# Altium schematic sheet HeartAware_AnalogSensorControl.SchDoc
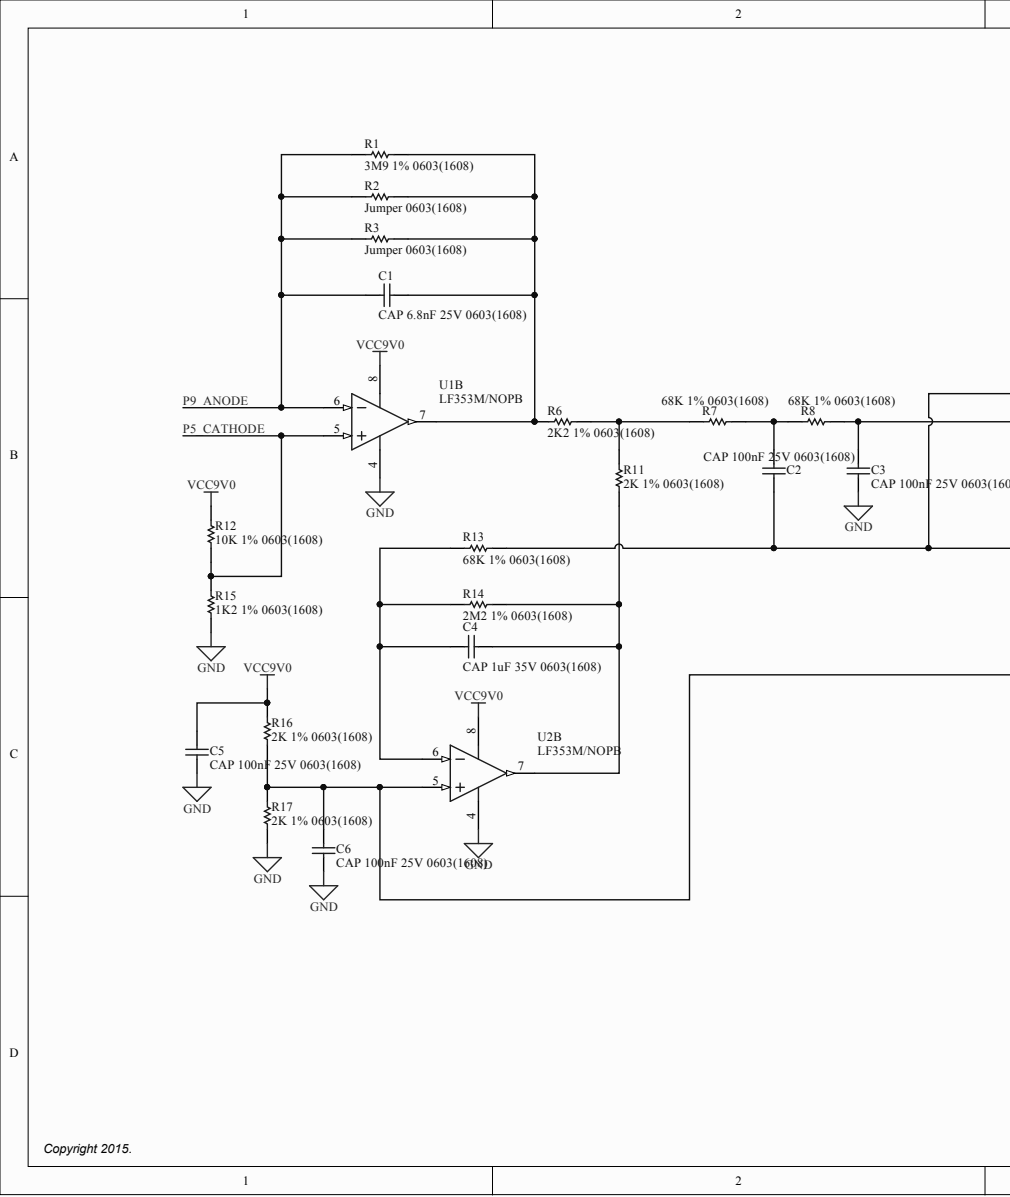
[diagram p0: HeartAware_AnalogSensorControl.SchDoc, left]
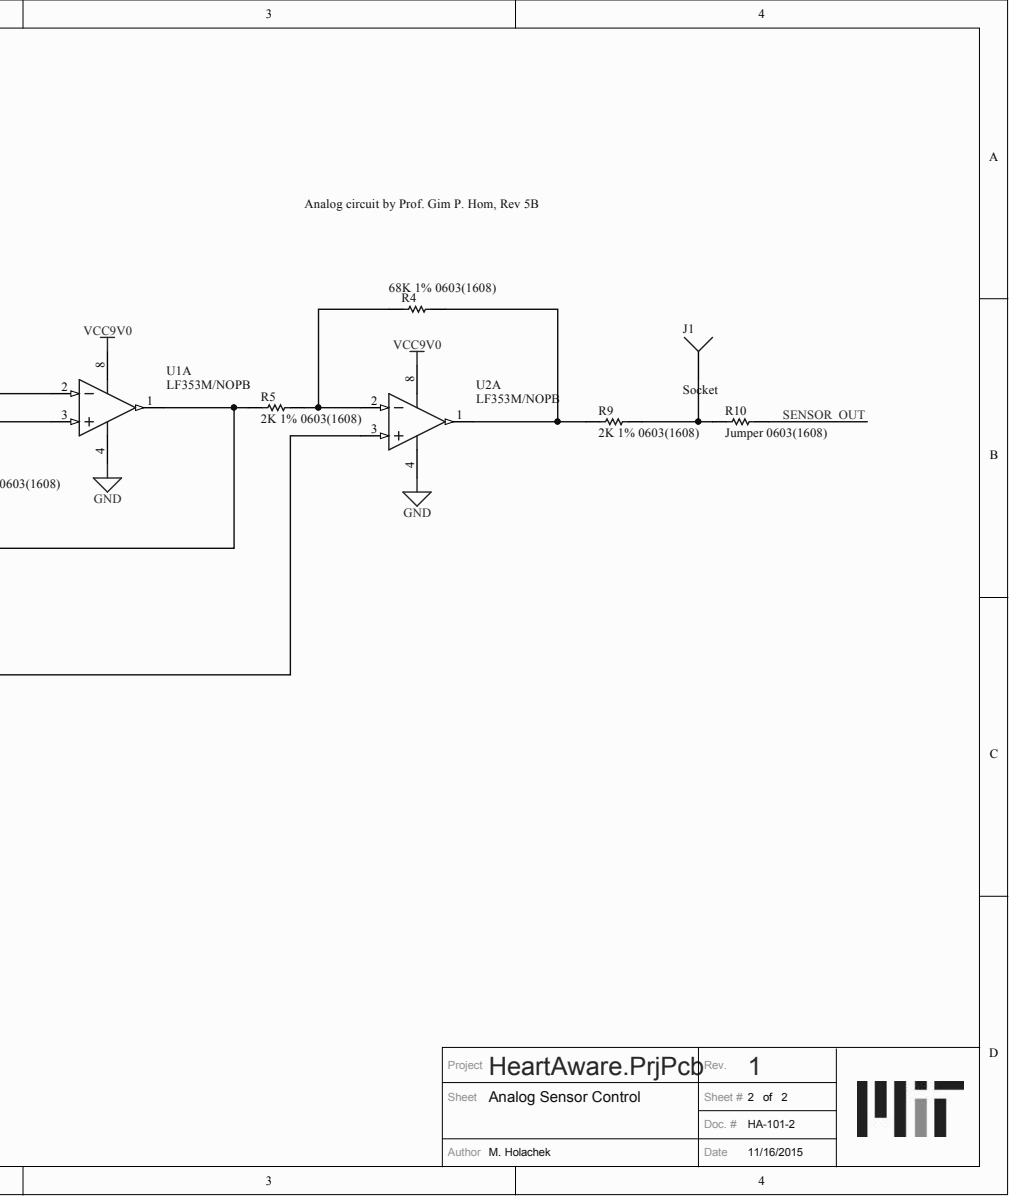
[diagram p1: HeartAware_AnalogSensorControl.SchDoc, right]

## Parts
C1  CAP 6.8nF 25V 0603(1608)  value 6.8nF  [CAPC0603(1608)100_N]
C2  CAP 100nF 25V 0603(1608)  value 100nF  [CAPC0603(1608)100_M]
C3  CAP 100nF 25V 0603(1608)  value 100nF  [CAPC0603(1608)100_M]
C4  CAP 1uF 35V 0603(1608)  value 1uF  [CAPC0603(1608)100_L]
C5  CAP 100nF 25V 0603(1608)  value 100nF  [CAPC0603(1608)100_M]
C6  CAP 100nF 25V 0603(1608)  value 100nF  [CAPC0603(1608)100_M]
J1  Socket  [PIN1]
R1  3M9 1% 0603(1608)  [RESC0603(1608)_M]
R2  Jumper 0603(1608)  [RESC0603(1608)_N]
R3  Jumper 0603(1608)  [RESC0603(1608)_N]
R4  68K 1% 0603(1608)  [RESC0603(1608)_M]
R5  2K 1% 0603(1608)  [RESC0603(1608)_N]
R6  2K2 1% 0603(1608)  [RESC0603(1608)_L]
R7  68K 1% 0603(1608)  [RESC0603(1608)_M]
R8  68K 1% 0603(1608)  [RESC0603(1608)_M]
R9  2K 1% 0603(1608)  [RESC0603(1608)_N]
R10  Jumper 0603(1608)  [RESC0603(1608)_N]
R11  2K 1% 0603(1608)  [RESC0603(1608)_N]
R12  10K 1% 0603(1608)  [RESC0603(1608)_N]
R13  68K 1% 0603(1608)  [RESC0603(1608)_M]
R14  2M2 1% 0603(1608)  [RESC0603(1608)_M]
R15  1K2 1% 0603(1608)  [RESC0603(1608)_M]
R16  2K 1% 0603(1608)  [RESC0603(1608)_N]
R17  2K 1% 0603(1608)  [RESC0603(1608)_N]
U1  LF353M/NOPB  [M08A_M]  (parts A,B)
U2  LF353M/NOPB  [M08A_M]  (parts A,B)

## Nets
GND: C3.1 C5.1 C6.1 R15.1 R17.1 U1.4 U2.4
VCC9V0: C5.2 R12.1 R16.2 U1.8 U2.8
P5_CATHODE: R12.2 R15.2 U1.5
P9_ANODE: C1.1 R1.1 R2.1 R3.1 U1.6
SENSOR_OUT: R10.2
NetC1_2: C1.2 R1.2 R2.2 R3.2 R6.1 U1.7
NetC2_1: C2.1 R5.1 R13.1 U1.1 U1.2
NetC2_2: C2.2 R7.2 R8.1
NetC3_2: C3.2 R8.2 U1.3
NetC4_1: C4.1 R13.2 R14.1 U2.6
NetC4_2: C4.2 R11.1 R14.2 U2.7
NetC6_2: C6.2 R16.1 R17.2 U2.3 U2.5
NetJ1_1: J1.1 R9.2 R10.1
NetR4_1: R4.1 R5.2 U2.2
NetR4_2: R4.2 R9.1 U2.1
NetR6_2: R6.2 R7.1 R11.2

## Library data of the parts
C1 = CMP-1035-02265-1: CAP 6.8nF 25V Â±20% 0603 (1608 Metric) Thickness 1mm SMD; Case-EIA: 0603; Case-Metric: 1608; Component Kind: Standard; Library Name: Altium Content Vault; Max Thickness: 1 mm; Rated Voltage: 25 V; Technology: SMT; Tolerance: Â±20%
C2 C3 C5 C6 = CMP-1035-02588-1: CAP 100nF 25V Â±10% 0603 (1608 Metric) Thickness 1mm SMD; Case-EIA: 0603; Case-Metric: 1608; Component Kind: Standard; Library Name: Altium Content Vault; Max Thickness: 1 mm; Rated Voltage: 25 V; Technology: SMT; Tolerance: Â±10%
  C5: Value: 100nF
C4 = CMP-1035-02743-1: CAP 1uF 35V Â±10% 0603 (1608 Metric) Thickness 1mm SMD; Case-EIA: 0603; Case-Metric: 1608; Component Kind: Standard; Library Name: Altium Content Vault; Max Thickness: 1 mm; Rated Voltage: 35 V; Technology: SMT; Tolerance: Â±10%
R1 = CMP-1012-00915-1: 3M9 0.1W 1% 0603 (1608 Metric)  SMD; Case-EIA: 0603; Case-Metric: 1608; Value: 3900000.00 Ohm; Component Kind: Standard; Library Name: Altium Content Vault; Power: 0.1 W; Technology: SMT; Tolerance: 1 %
R2 R3 R10 = CMP-1012-00001-1: Jumper 0603 (1608 Metric); Case-EIA: 0603; Case-Metric: 1608; Power: None; Value: 0.00 Ohm; Component Kind: Standard; Library Name: Altium Content Vault; Technology: SMT; Tolerance: None
R4 R7 R8 R13 = CMP-1012-00717-1: 68K 0.1W 1% 0603 (1608 Metric)  SMD; Case-EIA: 0603; Case-Metric: 1608; Value: 68000.00 Ohm; Component Kind: Standard; Library Name: Altium Content Vault; Power: 0.1 W; Technology: SMT; Tolerance: 1 %
R5 R9 R11 R16 R17 = CMP-1012-00542-1: 2K 0.1W 1% 0603 (1608 Metric)  SMD; Case-EIA: 0603; Case-Metric: 1608; Value: 2000.00 Ohm; Component Kind: Standard; Library Name: Altium Content Vault; Power: 0.1 W; Technology: SMT; Tolerance: 1 %
R6 = CMP-1012-00546-1: 2K2 0.1W 1% 0603 (1608 Metric)  SMD; Case-EIA: 0603; Case-Metric: 1608; Value: 2200.00 Ohm; Component Kind: Standard; Library Name: Altium Content Vault; Power: 0.1 W; Technology: SMT; Tolerance: 1 %
R12 = CMP-1012-00623-1: 10K 0.1W 1% 0603 (1608 Metric)  SMD; Case-EIA: 0603; Case-Metric: 1608; Value: 10000.00 Ohm; Component Kind: Standard; Library Name: Altium Content Vault; Power: 0.1 W; Technology: SMT; Tolerance: 1 %
R14 = CMP-1012-00885-1: 2M2 0.1W 1% 0603 (1608 Metric)  SMD; Case-EIA: 0603; Case-Metric: 1608; Value: 2200000.00 Ohm; Component Kind: Standard; Library Name: Altium Content Vault; Power: 0.1 W; Technology: SMT; Tolerance: 1 %
R15 = CMP-1012-00518-1: 1K2 0.1W 1% 0603 (1608 Metric)  SMD; Case-EIA: 0603; Case-Metric: 1608; Value: 1200.00 Ohm; Component Kind: Standard; Library Name: Altium Content Vault; Power: 0.1 W; Technology: SMT; Tolerance: 1 %
U1 U2 = CMP-0055-00856-2: Wide Bandwidth Dual JFET Input Operational Amplifier, 8-pin Narrow SOIC, Pb-Free; Manufacturer: National Semiconductor; CompType: Amplifiers; PackageTopMarking: NSZXTT*LF353*M; PowerWise: N; Code_IPC: SOIC127P600-8; Code_JEDEC: MS-012-AA; Component Kind: Standard; ComponentLink1Description: LF353 Datasheet; ComponentLink1URL: http://www.national.com/ds/LF/LF353.pdf; ComponentLink2Description: LF353 Web Page; ComponentLink2URL: http://www.national.com/pf/LF/LF353.html; ComponentLink3Description: M08A Package Page; ComponentLink3URL: http://www.national.com/packaging/folders/m08a.html; DatasheetVersion: Dec-2003; Library Name: Altium Content Vault; PackageDescription: SOIC Narrow, 4.9 x 3.9 x 1.45mm, 8 Lead, 1.27mm Pitch; PackageReference: M08A; PackageVersion: revM, May-2009; PinCount: 8; RevisionNote: Component URLs added; RoHS: Y
J1 = Socket: Component Kind: Standard; Description: Socket; LatestRevisionDate: 17-Jul-2002; LatestRevisionNote: Re-released for DXP Platform.; Library Name: Miscellaneous Connectors.IntLib; Signal Integrity: Connector; Publisher: Altium Limited
